# Altium schematic sheet 5V 5A Power Supply.SchDoc
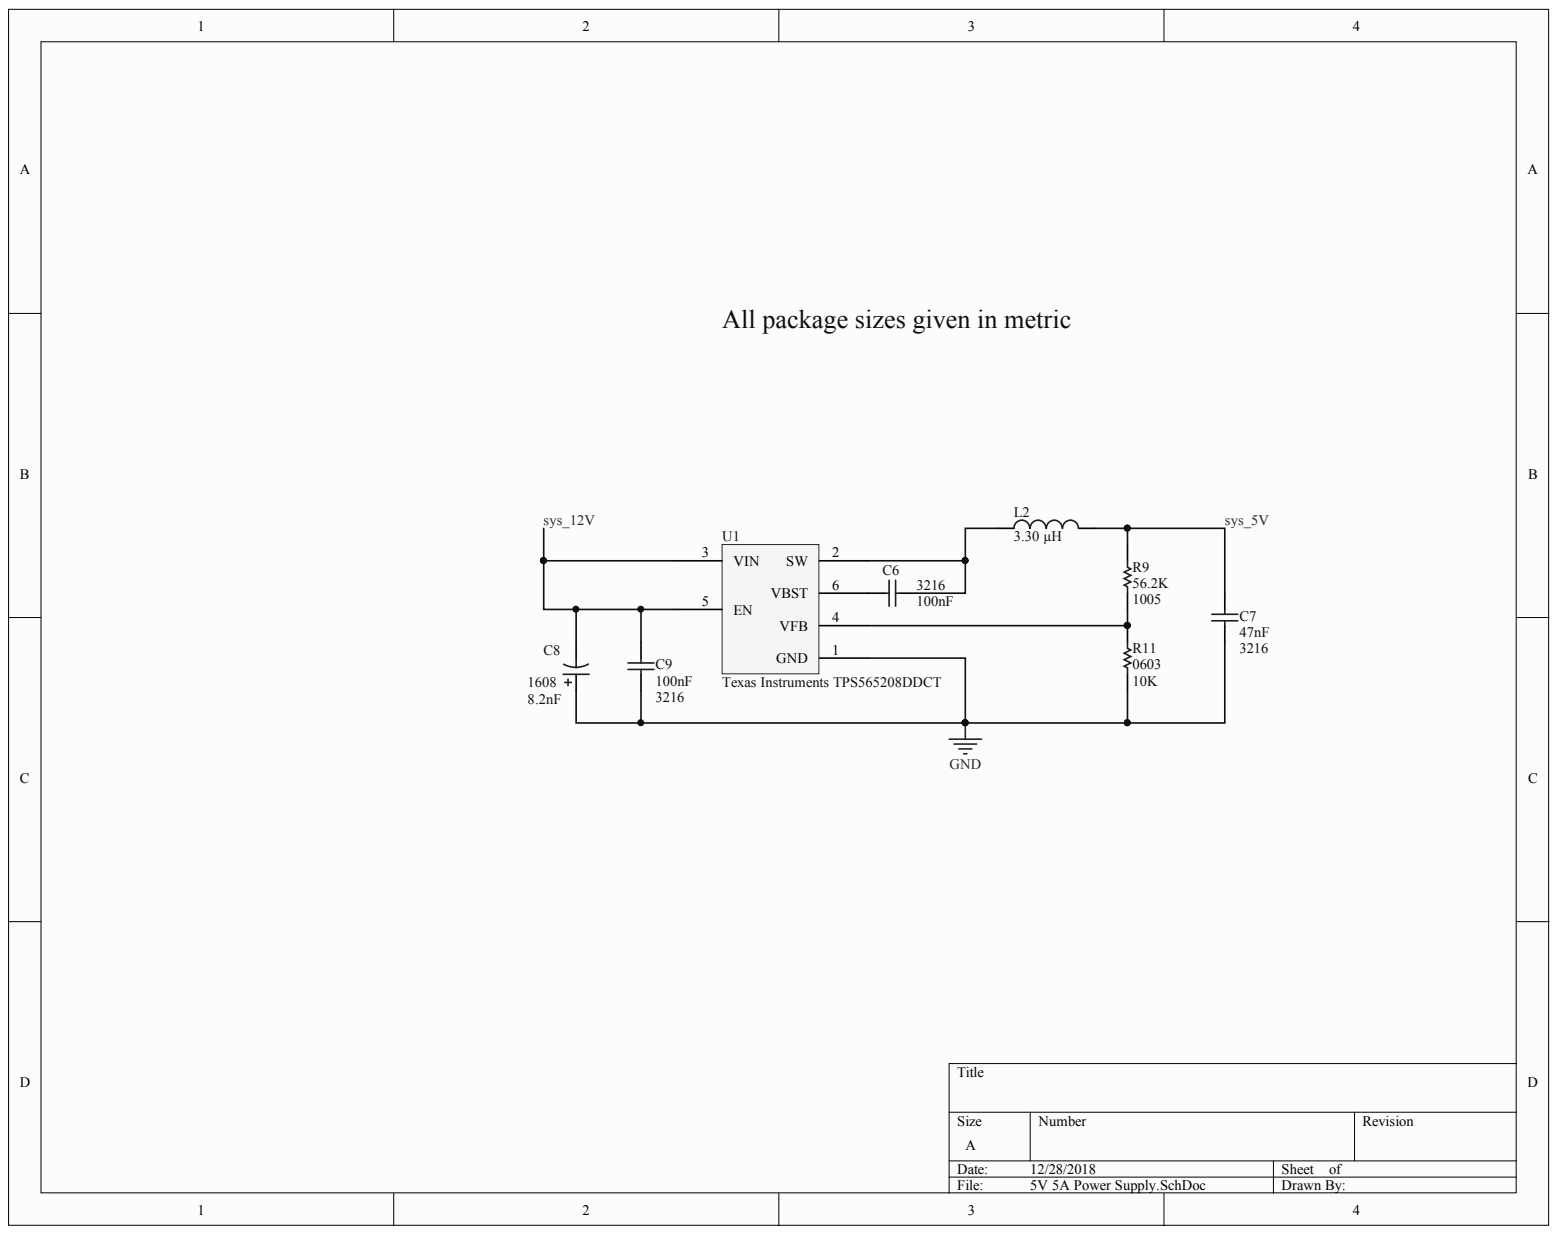
[diagram p0: 5V 5A Power Supply.SchDoc]

## Parts
C6  Capacitance: 100nF  Case/Package: 3216  [CAPC3216X100X50ML20T25]  (hidden comment: Kemet C1206F224K1RACTU)
C7  Capacitance: 47nF  Case/Package: 3216  [CAPC3216X100X50ML20T25]  (hidden comment: Kemet C1206F224K1RACTU)
C8  Capacitance: 8.2nF  Case/Package: 1608  [PCB-asfpcoqvmjw65b2ui7gq-1]  (hidden comment: Cap Pol2)
C9  Capacitance: 100nF  Case/Package: 3216  [CAPC3216X100X50ML20T25]  (hidden comment: Kemet C1206F224K1RACTU)
L2  Inductance: 3.30 µH  [PCB-5z2rdkkp4kwa131j9pjb-1]  (hidden comment: Cooper Bussmann DR127-3R3-R)
R9  Case/Package: 1005  Resistance: 56.2K  [RES-0402_AP200]  (hidden comment: Vishay CRCW0402330RFKED)
R11  Case/Package (SI): 0603  Resistance: 10K  [RESC0603X28X15ML05T05]  (hidden comment: Vishay CRCW02010000Z0ED)
U1  Texas Instruments TPS565208DDCT  Manufacturer Part Number: TPS565208DDCT  [PCB-et1arjuf664i5cype8dm-1]

## Nets
GND: C7.1 C8.1 C9.1 R11.1 U1.1(GND)
SYS_5V: C7.2 L2.2 R9.2
SYS_12V: C8.2 C9.2 U1.3(VIN) U1.5(EN)
NetC6_1: C6.1 L2.1 U1.2(SW)
NetC6_2: C6.2 U1.6(VBST)
NetR9_1: R9.1 R11.2 U1.4(VFB)

## Library data of the parts
C6 C7 C9 = CMP-158244-2: Multilayer Ceramic Capacitors MLCC - SMD/SMT 100volts 0.22uF X7R 10%; Lead-Free Status: R; Voltage Rating (DC): 100.0 V; Capacitance Tolerance: ±10%; Component Kind: Standard; Dielectric Characteristic: X7R; Dielectric Material: Ceramic; Library Name: CommunityVault; Manufacturer Part Number: C1206F224K1RACTU; Mounting Style: Surface Mount; OctopartID: f3193b1bdd422cde; Octopart Url: https://octopart.com/c1206f224k1ractu-kemet-17304381; Packaging: Cut Tape (CT); REACH SVHC Compliance: No SVHC; RoHS: Compliant
C8 = CMP-12914703-5: Cap Tant Solid 2.2uF 10V M CASE 20% (1.6 X 0.85 X 0.8mm) SMD 1608 0.5 Ohm 105Â°C T/R; Equivalent Series Resistance (ESR): 500 mΩ; Voltage Rating (DC): 10.0 V; Capacitance Tolerance: ±20%; Component Kind: Standard; Library Name: CommunityVault; Mounting Style: Surface Mount; OctopartID: 025bca9e5b4bdd9c; Octopart URL: http://octopart.com/f381a225mma-avx-30375030; Packaging: Tape & Reel (TR), Cut Tape (CT); REACH SVHC Compliance: No SVHC; RoHS: Compliant
L2 = CMP-d6e71f5dd44c0920-2: Inductor Power Shielded Drum Core 2.831uH/3.3uH 20% 100KHz Ferrite 10.5A 5.67mOhm DCR T/R; Lead-Free Status: Lead Free; Shielding: Yes; Component Kind: Standard; Library Name: CommunityVault; Manufacturer Part Number: DR127-3R3-R; Mounting Style: Surface Mount; Number of Pins: 2; OctopartID: d6e71f5dd44c0920; Octopart URL: http://octopart.com/dr127-3r3-r-cooper+bussmann-11544525; Packaging: Cut Tape (CT); REACH SVHC Compliance: No SVHC; RoHS: Compliant; Size-Height: 8.00 mm; Size-Length: 12.5 mm; Size-Width: 12.5 mm
R9 = CMP-1856492-9: Vishay; Lead-Free Status: Lead Free; Power Rating: 0.063 W; Resistance Tolerance: ±1%; Temperature Coefficient: 100.0 ppm/°C; Voltage Rating (DC): 50.0 V; Component Kind: Standard; Library Name: CommunityVault; Manufacturer Part Number: CRCW0402330RFKED; Mounting Style: Surface Mount; Number of Pins: 2; OctopartID: c4ce0f9b72b01b09; Octopart Url: http://octopart.com/crcw0402330rfked-vishay-39818008; REACH SVHC Compliance: No SVHC; RoHS: Compliant; Seller Landing  Url: https://octopart-clicks.com/click/track?country=US&ak=8157f166&sig=07c2344&sid=5489&ppid=39818008&vpid=168884828&ct=offers; Size-Height: 0.00035 m; Size-Length: 0.001 m; Size-Width: 0.0005 m
R11 = CMP-1859241-2: RES SMD 0.0 OHM JUMPER 1/20W; Lead-Free Status: Lead Free; Power Rating: 0.05 W; Resistance Tolerance: ±5%; Voltage Rating (DC): 30.0 V; Case/Package: 0201; Component Kind: Standard; Library Name: CommunityVault; Manufacturer Part Number: CRCW02010000Z0ED; Mounting Style: Surface Mount; Number of Pins: 2; OctopartID: 5e2b95433f4781ec; Octopart Url: https://octopart.com/crcw02010000z0ed-vishay-39994378; REACH SVHC Compliance: No SVHC; RoHS: Compliant; Size-Height: 0.00023 m; Size-Length: 0.0006 m; Size-Width: 0.0003 m
U1 = CMP-72babe9d8e3fad7d-3: Conv DC-DC 4.5V to 17V Synchronous Step Down/Step Up Single-Out 0.76V to 7V 5A 6-Pin TSOT-23 T/R; Number of Regulated Outputs: 1; Quiescent Current: 600 µA; Component Kind: Standard; Library Name: CommunityVault; Lifecycle Status: Active; OctopartID: 72babe9d8e3fad7d; Octopart URL: https://octopart.com/tps565208ddct-texas+instruments-86890979; RoHS: Compliant
